# Altium schematic sheet LLP_Simple_LEDs.SchDoc(LLP_Simple_LEDs)
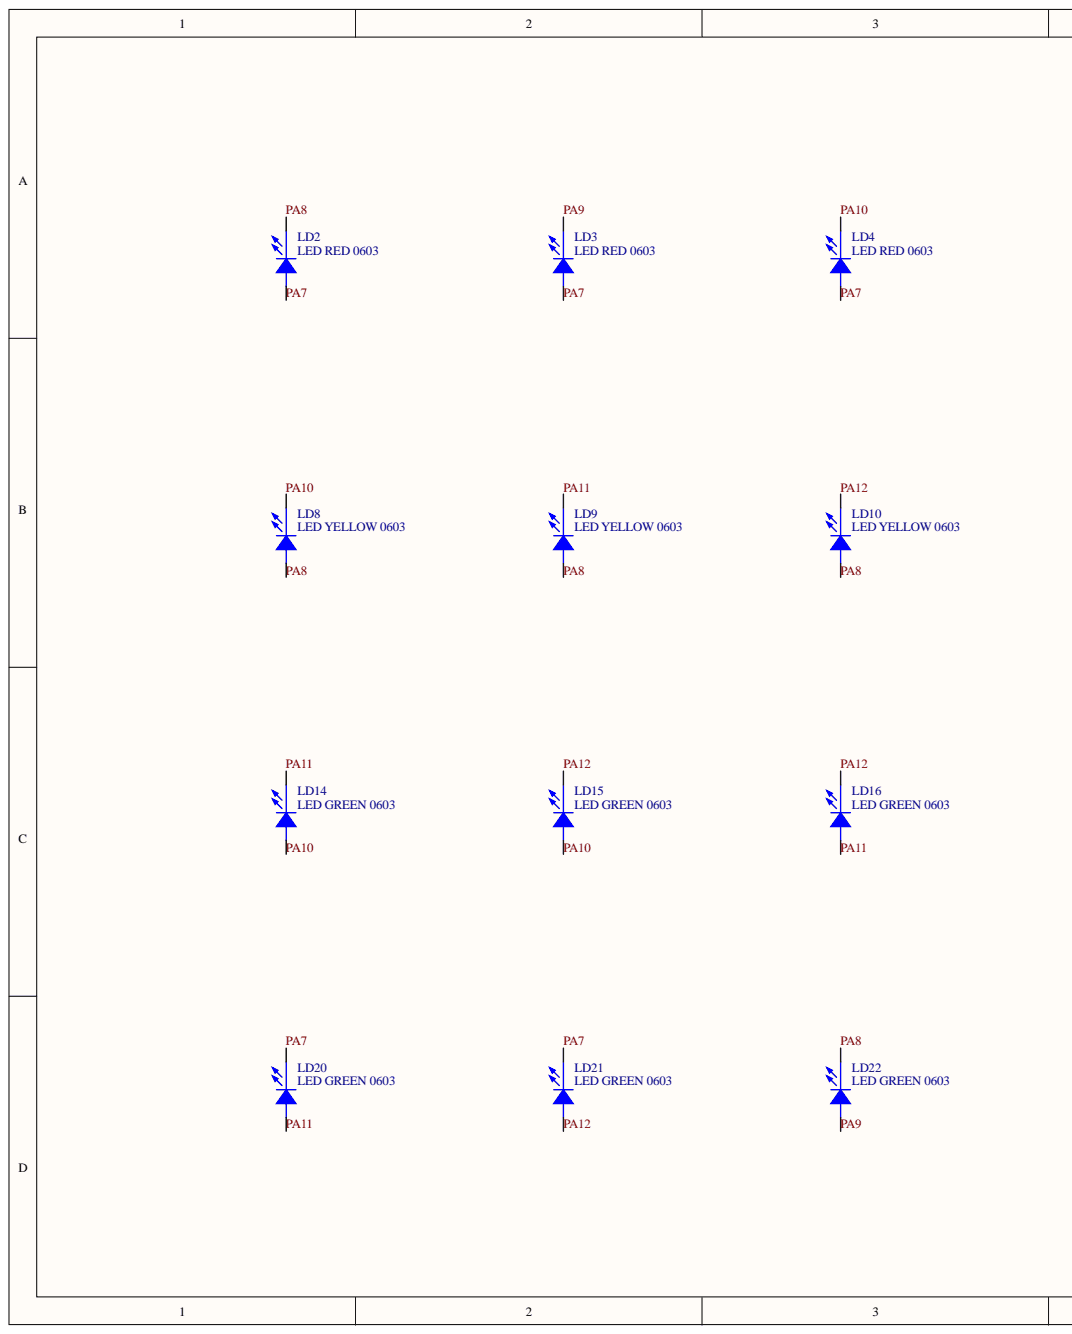
[diagram p0: LLP_Simple_LEDs.SchDoc, left]
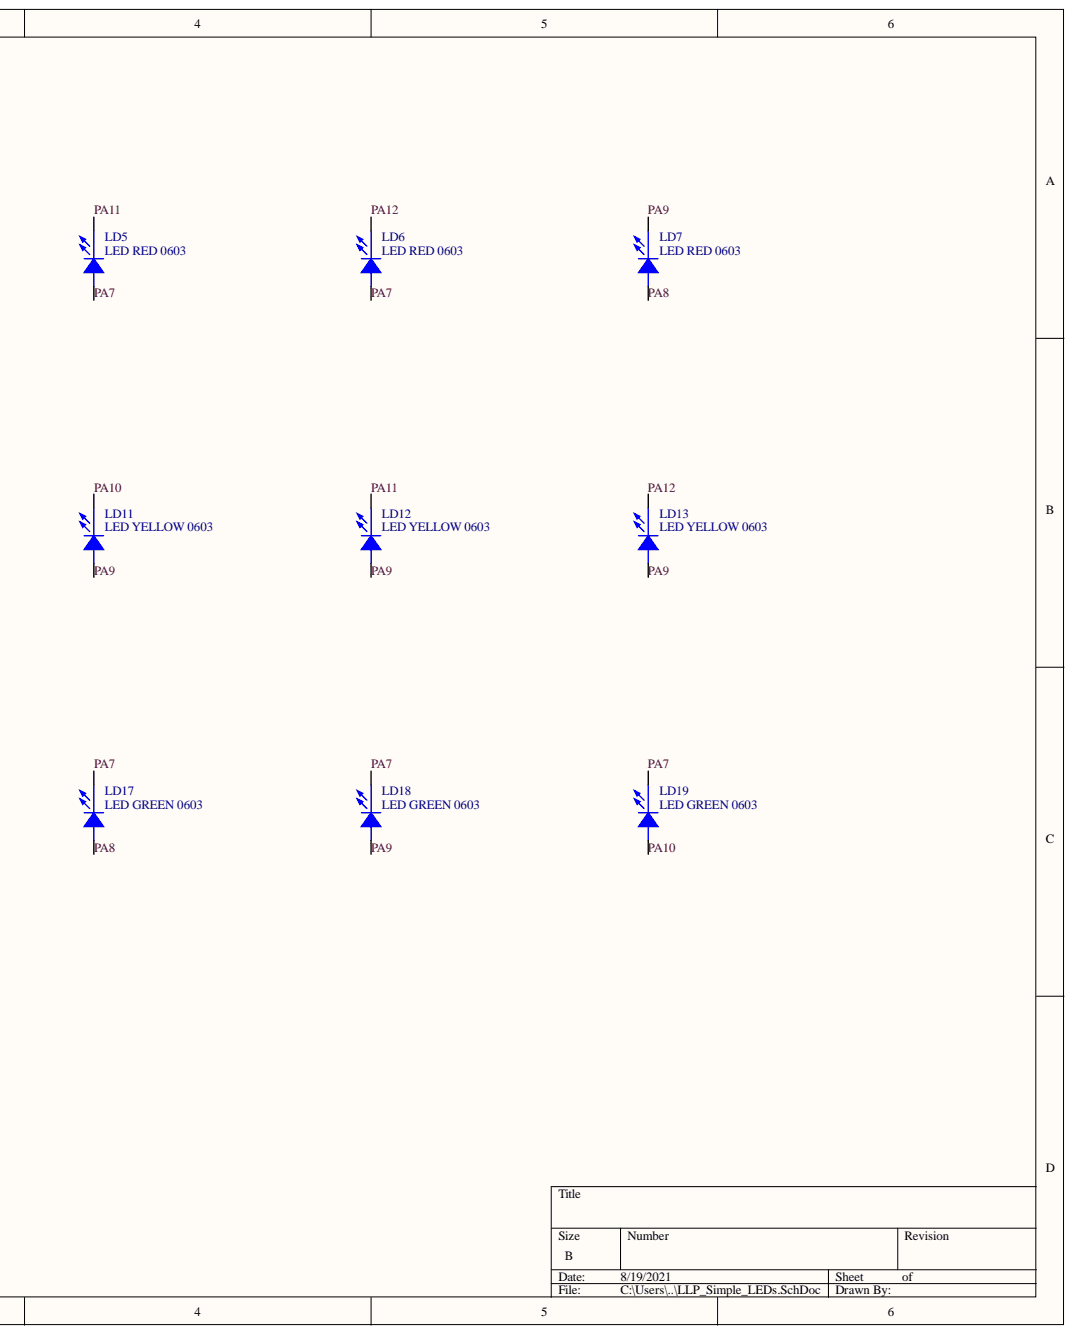
[diagram p1: LLP_Simple_LEDs.SchDoc, right]

## Parts
LD2  LED RED 0603  COLOR: RED  [0603LED_RED]
LD3  LED RED 0603  COLOR: RED  [0603LED_RED]
LD4  LED RED 0603  COLOR: RED  [0603LED_RED]
LD5  LED RED 0603  COLOR: RED  [0603LED_RED]
LD6  LED RED 0603  COLOR: RED  [0603LED_RED]
LD7  LED RED 0603  COLOR: RED  [0603LED_RED]
LD8  LED YELLOW 0603  COLOR: YELLOW  [0603LED_YELLOW]
LD9  LED YELLOW 0603  COLOR: YELLOW  [0603LED_YELLOW]
LD10  LED YELLOW 0603  COLOR: YELLOW  [0603LED_YELLOW]
LD11  LED YELLOW 0603  COLOR: YELLOW  [0603LED_YELLOW]
LD12  LED YELLOW 0603  COLOR: YELLOW  [0603LED_YELLOW]
LD13  LED YELLOW 0603  COLOR: YELLOW  [0603LED_YELLOW]
LD14  LED GREEN 0603  COLOR: GREEN  [0603LED_GREEN]
LD15  LED GREEN 0603  COLOR: GREEN  [0603LED_GREEN]
LD16  LED GREEN 0603  COLOR: GREEN  [0603LED_GREEN]
LD17  LED GREEN 0603  COLOR: GREEN  [0603LED_GREEN]
LD18  LED GREEN 0603  COLOR: GREEN  [0603LED_GREEN]
LD19  LED GREEN 0603  COLOR: GREEN  [0603LED_GREEN]
LD20  LED GREEN 0603  COLOR: GREEN  [0603LED_GREEN]
LD21  LED GREEN 0603  COLOR: GREEN  [0603LED_GREEN]
LD22  LED GREEN 0603  COLOR: GREEN  [0603LED_GREEN]

## Nets
PA7: LD2.2 LD3.2 LD4.2 LD5.2 LD6.2 LD17.1 LD18.1 LD19.1 LD20.1 LD21.1
PA8: LD2.1 LD7.2 LD8.2 LD9.2 LD10.2 LD17.2 LD22.1
PA9: LD3.1 LD7.1 LD11.2 LD12.2 LD13.2 LD18.2 LD22.2
PA10: LD4.1 LD8.1 LD11.1 LD14.2 LD15.2 LD19.2
PA11: LD5.1 LD9.1 LD12.1 LD14.1 LD16.2 LD20.2
PA12: LD6.1 LD10.1 LD13.1 LD15.1 LD16.1 LD21.2

## Library data of the parts
LD2-LD7 = LED_0603_R: 0603 Ultra Bright Red Led, 54mcd; PART#: LTST-C191KRKT; WB: 1; VOLTAGE: Vf=2.0V; QTY_TILL: 50000; QTY_FROM: 15000; PID: 24352; MF: Lite-On Singapore Pte Ltd (istais); DESC: Ultrabright LED Red 631nm 54mcd/130deg 0603 SMD; ACCEPT: ENGINEER; VALUE: 54mcd; SMD: 1; PRICE: 0,01225; Library Name: LED.IntLib; HELPURL: http://kravad.mt.lv/kravad/files/nekJghJthpkvE5Kb8s7ThmJZaCwRfK2K; FP: 24352; DATE_TILL: 17.03.2088; DATE_FROM: 19.01.2015; Component Kind: Standard
LD8-LD13 = LED_0603_YELLOW_SLM: ULTRABRIGHT LED YELLOW 0603 0.8mm high; PART#: SLM-0603UY4A-EC; WB: 1; VOLTAGE: Vf=2.2V; VALUE: 591nm; QTY_TILL: 130000; QTY_FROM: 10000; PID: 11751; MF: SHARLIGHT ELECTRONICS CO., LTD; FP: 0603; DESC: Ultra bright yellow SMD LED 0603, 135mcd/125deg, RoHS, Tape&Reel; ACCEPT: ENGINEER; SMD: 1; PRICE: 0,014; Library Name: LED.IntLib; HELPURL: http://kravad.mt.lv/kravad/files/zzYBwrC9YuoChHb7RcH8GxAVf3y9CXan; DATE_TILL: 01.01.2099; DATE_FROM: 05.10.2015; COMPONENTLINK1URL: http://kravad.mt.lv/kravad/files/VzDVZfBBVbjFvzf4mxdMK4HbYDvNL8N9; COMPONENTLINK1DESCRIPTION: Selected Part_Datasheet 27009; Component Kind: Standard
LD14-LD22 = LED_0603_G_SLM_OLD: LED GREEN 0603 0.8mm high; PART#: SLM-0603240-BL; WB: 1; VOLTAGE: Vf=2.1V; QTY_FROM: 9000; PID: 3898; MF: SHARLIGHT ELECTRONICS CO., LTD; FP: 0603; DESC: Green SMD LED (RoHS) 0603, 0.8mm high 12mcd; ACCEPT: ENGINEER; SMD: 1; PRICE: 0,01; Library Name: LED.IntLib; HELPURL: http://kravad.mt.lv/kravad/files/HfoZHdWvBzYZGzmrwHEksVeJER5kcH5b; DATE_TILL: 01.01.2099; DATE_FROM: 18.03.2015; COMPONENTLINK1URL: http://kravad.mt.lv/kravad/files/GYMVWT4kr3gtfRdG6Gnz5bygWBD5sxHN; COMPONENTLINK1DESCRIPTION: Selected Part_Datasheet 24351; Component Kind: Standard
